# Altium schematic sheet Controller.SchDoc
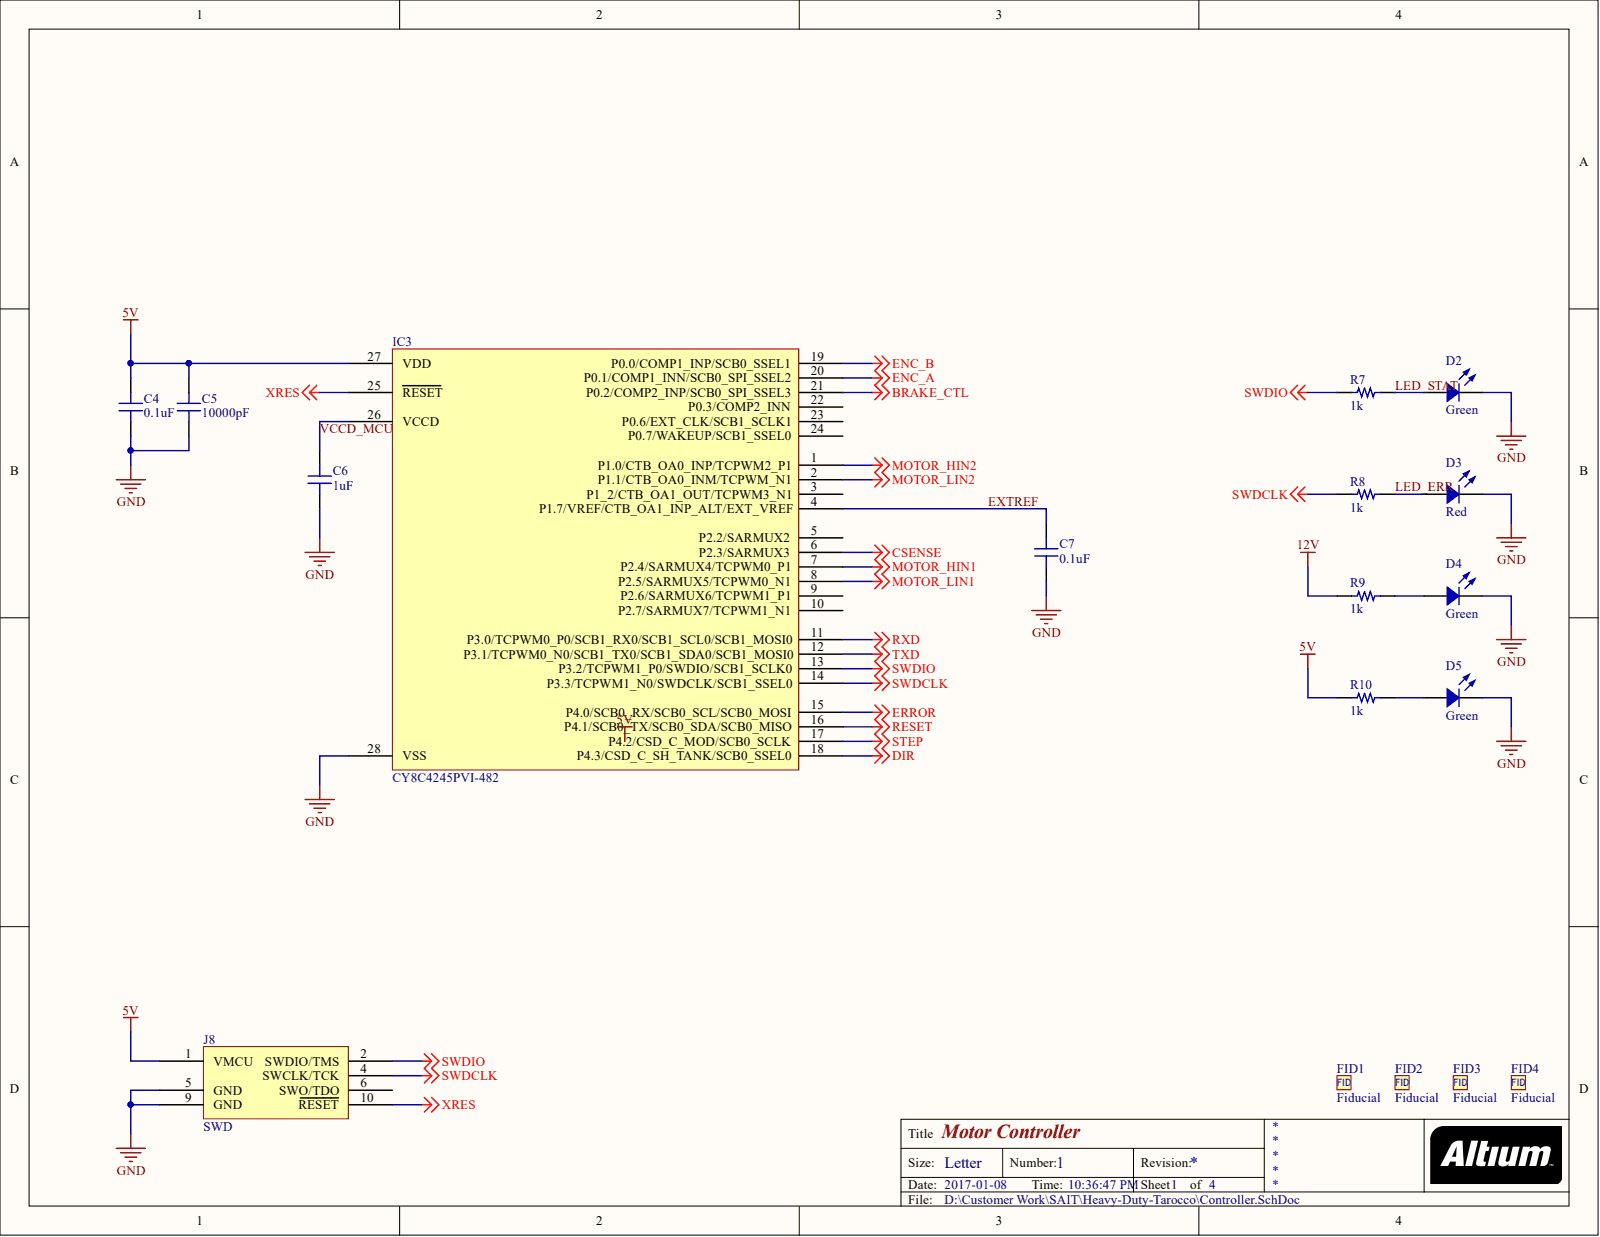
[diagram p0: Controller.SchDoc]

## Parts
C4  0.1uF  [CAP 0603/1608]
C5  10000pF  [CAP 0603/1608]
C6  1uF  [CAP 0603/1608]
C7  0.1uF  [CAP 0603/1608]
D2  Green  [LED 0603/1608 GREEN]
D3  Red  [LED 0603/1608 RED]
D4  Green  [LED 0603/1608 GREEN]
D5  Green  [LED 0603/1608 GREEN]
FID1  Fiducial  [Fiducial]  (no BOM)
FID2  Fiducial  [Fiducial]  (no BOM)
FID3  Fiducial  [Fiducial]  (no BOM)
FID4  Fiducial  [Fiducial]  (no BOM)
IC3  CY8C4245PVI-482  [CYPRESS SSOP-28 210MIL]
J8  SWD  [10 Pin SWD]  (no BOM)
R7  1k  [RES 0603/1608]
R8  1k  [RES 0603/1608]
R9  1k  [RES 0603/1608]
R10  1k  [RES 0603/1608]

## Nets
5V: C4.2 C5.2 IC3.27(VDD) J8.1(VMCU) R10.1
12V: R9.1
BRAKE_CTL: IC3.21(P0.2/COMP2_INP/SCB0_SPI_SSEL3)
CSENSE: IC3.6(P2.3/SARMUX3)
DIR: IC3.18(P4.3/CSD_C_SH_TANK/SCB0_SSEL0)
ENC_A: IC3.20(P0.1/COMP1_INN/SCB0_SPI_SSEL2)
ENC_B: IC3.19(P0.0/COMP1_INP/SCB0_SSEL1)
ERROR: IC3.15(P4.0/SCB0_RX/SCB0_SCL/SCB0_MOSI)
GND: C4.1 C5.1 C6.1 C7.1 D2.2 D3.2 D4.2 D5.2 IC3.28(VSS) J8.5(GND) J8.9(GND)
MOTOR_HIN1: IC3.7(P2.4/SARMUX4/TCPWM0_P1)
MOTOR_HIN2: IC3.1(P1.0/CTB_OA0_INP/TCPWM2_P1)
MOTOR_LIN1: IC3.8(P2.5/SARMUX5/TCPWM0_N1)
MOTOR_LIN2: IC3.2(P1.1/CTB_OA0_INM/TCPWM_N1)
RESET: IC3.16(P4.1/SCB0_TX/SCB0_SDA/SCB0_MISO)
RXD: IC3.11(P3.0/TCPWM0_P0/SCB1_RX0/SCB1_SCL0/SCB1_MOSI0)
STEP: IC3.17(P4.2/CSD_C_MOD/SCB0_SCLK)
SWDCLK: IC3.14(P3.3/TCPWM1_N0/SWDCLK/SCB1_SSEL0) J8.4(SWCLK/TCK) R8.1
SWDIO: IC3.13(P3.2/TCPWM1_P0/SWDIO/SCB1_SCLK0) J8.2(SWDIO/TMS) R7.1
TXD: IC3.12(P3.1/TCPWM0_N0/SCB1_TX0/SCB1_SDA0/SCB1_MOSI0)
XRES: IC3.25(RESET) J8.10(RESET)
EXTREF: C7.2 IC3.4(P1.7/VREF/CTB_OA1_INP_ALT/EXT_VREF)
LED_ERR: D3.1 R8.2
LED_STAT: D2.1 R7.2
VCCD_MCU: C6.2 IC3.26(VCCD)
NetD4_1: D4.1 R9.2
NetD5_1: D5.1 R10.2

Not connected: IC3 3(P1_2/CTB_OA1_OUT/TCPWM3_N1) 5(P2.2/SARMUX2) 9(P2.6/SARMUX6/TCPWM1_P1) 10(P2.7/SARMUX7/TCPWM1_N1) 22(P0.3/COMP2_INN) 23(P0.6/EXT_CLK/SCB1_SCLK1) 24(P0.7/WAKEUP/SCB1_SSEL0); J8 6(SWO/TDO)

## Library data of the parts
C4 = CC0603KPX7R7BB104: CAP CER 0.1UF 16V X7R 0603; Manufacturer: Yageo; Database Table Name: Capacitor - Ceramic; TemperatureRange: -55C ~ 125C; Tolerance: 10%; Component Kind: Standard; ComponentLink1Description: Datasheet; ComponentLink1URL: http://www.yageo.com/documents/recent/UPY-GPHC_X7R_6.3V-to-50V-v12.pdf; ComponentLink2Description: Digi-Key listing; ComponentLink2URL: http://digikey.com/product-detail/en/CC0603KPX7R7BB104/311-1335-2-ND/2103073; Device Package: 0603 (1608 Metric); Library Name: Celestial Library Database.DbLib; PartId: 5262; Part Number: CC0603KPX7R7BB104; Price: 0.0043; Supplier 1: Digi-Key; Supplier Part Number 1: 311-1335-2-ND; TemperatureCoefficient: X7R; Type: -; Value: 0.1uF; Voltage: 16V
C5 = CL10B103KB8NCNC: CAP CER 10000PF 50V X7R 0603; Manufacturer: Samsung Electro-Mechanics America, Inc.; Database Table Name: Capacitor - Ceramic; TemperatureRange: -55C ~ 125C; Tolerance: 10%; Component Kind: Standard; ComponentLink1Description: Datasheet; ComponentLink1URL: http://www.samsungsem.com/kr/support/library/product-catalog/__icsFiles/afieldfile/2015/11/18/MLCC.pdf; ComponentLink2Description: Digi-Key Listing; ComponentLink2URL: https://www.digikey.com/product-detail/en/samsung-electro-mechanics-america-inc/CL10B103KB8NCNC/1276-1921-2-ND/3887579; Device Package: 0603 (1608 Metric); Library Name: Celestial Library Database.DbLib; PartId: 13008; Part Number: CL10B103KB8NCNC; Price: 0.0032; Supplier 1: Digi-Key; Supplier Part Number 1: 1276-1921-2-ND; TemperatureCoefficient: X7R; Value: 10000pF; Voltage: 50V
C6 = CC0603ZRY5V7BB105: CAP CER 1UF 16V Y5V 0603; Manufacturer: Yageo; Database Table Name: Capacitor - Ceramic; TemperatureCoefficient: Y5V (F); TemperatureRange: -30C ~ 85C; Tolerance: -20%, +80%; Component Kind: Standard; ComponentLink1Description: Datasheet; ComponentLink1URL: http://www.yageo.com/documents/recent/UPY-GPHC_Y5V_6.3V-to-50V_6.pdf; ComponentLink2Description: Digi-Key Listing; ComponentLink2URL: https://www.digikey.com/product-detail/en/yageo/CC0603ZRY5V7BB105/311-1372-2-ND/2103110; Device Package: 0603 (1608 Metric); Library Name: Celestial Library Database.DbLib; PartId: 13115; Part Number: CC0603ZRY5V7BB105; Price: 0.0063; Supplier 1: Digi-Key; Supplier Part Number 1: 311-1372-2-ND; Value: 1uF; Voltage: 16V
C7 = CC0603JRX7R8BB104: CAP CER 0.1UF 25V X7R 0603; Manufacturer: Yageo; Database Table Name: Capacitor - Ceramic; TemperatureRange: -55C ~ 125C; Tolerance: 5%; Component Kind: Standard; ComponentLink1Description: Datasheet; ComponentLink1URL: http://www.yageo.com/documents/recent/UPY-GPHC_X7R_6.3V-to-50V-v12.pdf; ComponentLink2Description: Digi-Key listing; ComponentLink2URL: http://digikey.com/product-detail/en/CC0603JRX7R8BB104/311-1777-2-ND/5195186; Device Package: 0603 (1608 Metric); Library Name: Celestial Library Database.DbLib; PartId: 5305; Part Number: CC0603JRX7R8BB104; Price: 0.0079; Supplier 1: Digi-Key; Supplier Part Number 1: 311-1777-2-ND; TemperatureCoefficient: X7R; Type: -; Value: 0.1uF; Voltage: 25V
D2 D4 D5 = LTST-C191KGKT: LED GREEN CLEAR 0603 SMD; Manufacturer: Lite-On Inc.; Current (Test): 20mA; Database Table Name: Chip LED; Millicandela: 35mcd; Type: Surface Mount; Viewing Angle: 130; Voltage Forward: 2V; Colour: Green; Component Kind: Standard; ComponentLink1Description: Datasheet; ComponentLink1URL: http://optoelectronics.liteon.com/upload/download/DS22-2000-228/S_110_LTST-C191KGKT.pdf; ComponentLink2Description: Digi-Key Listing; ComponentLink2URL: http://www.digikey.ca/product-detail/en/LTST-C191KGKT/160-1446-2-ND/386835; Device Package: 0603 (1608 Metric); Lens Transparency: Clear; Library Name: Celestial Library Database.DbLib; PartId: 7051; Part Number: LTST-C191KGKT; Price: 0.0521; Supplier 1: Digi-Key; Supplier Part Number 1: 160-1446-2-ND; Value: Green
D3 = LTST-C191KRKT: LED RED CLEAR 0603 SMD; Manufacturer: Lite-On Inc.; Current (Test): 20mA; Database Table Name: Chip LED; Millicandela: 54mcd; Value: Green; Viewing Angle: 130; Voltage Forward: 2V; Colour: Red; Component Kind: Standard; ComponentLink1Description: Datasheet; ComponentLink1URL: http://optoelectronics.liteon.com/upload/download/DS22-2000-223/S_110_LTST-C191KRKT.pdf; ComponentLink2Description: Digi-Key Listing; ComponentLink2URL: http://www.digikey.ca/product-detail/en/LTST-C191KRKT/160-1447-2-ND/386837; Device Package: 0603 (1608 Metric); Lens Transparency: Clear; Library Name: Celestial Library Database.DbLib; PartId: 7050; Part Number: LTST-C191KRKT; Price: 0.0521; Supplier 1: Digi-Key; Supplier Part Number 1: 160-1447-2-ND
IC3 = CY8C4245PVI-482: IC MCU 32BIT 32KB FLASH 28SSOP; Manufacturer: Cypress Semiconductor Corp; Connectivity: IC, IrDA, LIN, Microwire, SmartCard, SPI, SSP, UART/USART; Core Processor: ARM Cortex-M0; Core Size: 32-Bit; Database Table Name: MCU - ARM; Data Converters: A/D 8x12b; Number Of IO: 22; Oscillator Type: Internal; Peripherals: Brown-out Detect/Reset, CapSense, LCD, LVD, POR, PWM, WDT; Program Memory Size: 32KB (32K x 8); Ram Size: 4K x 8; Speed: 48MHz; Voltage: 1.71 V ~ 5.5 V; Component Kind: Standard; ComponentLink1Description: Datasheet; ComponentLink1URL: http://www.cypress.com/?docID=46322; ComponentLink2Description: Digi-Key Listing; ComponentLink2URL: https://www.digikey.com/product-detail/en/cypress-semiconductor-corp/CY8C4245PVI-482/CY8C4245PVI-482-ND/4047448; Device Package: 28-SSOP (0.209", 5.30mm Width); Library Name: Celestial Library Database.DbLib; PartId: 21202; Part Number: CY8C4245PVI-482; Price: 3.75; Program Memory Type: FLASH; Series: PSOC® 4 CY8C42xx; Supplier 1: Digi-Key; Supplier Part Number 1: CY8C4245PVI-482-ND
R7-R10 = ERJ-3GEYJ102V: RES SMD 1K OHM 5% 1/10W 0603; Manufacturer: Panasonic Electronic Components; Database Table Name: Resistor - Chip; PowerRating: 0.1W, 1/10W; TemperatureCoefficient: 200ppm/C; TemperatureRange: -55C ~ 155C; Component Kind: Standard; ComponentLink1Description: Datasheet; ComponentLink1URL: http://industrial.panasonic.com/www-cgi/jvcr13pz.cgi?E+PZ+3+AOA0001+ERJ3GEYJ102V+7+WW; ComponentLink2Description: Digi-Key Listing; ComponentLink2URL: https://www.digikey.com/product-detail/en/panasonic-electronic-components/ERJ-3GEYJ102V/P1.0KGTR-ND/68472; Device Package: 0603 (1608 Metric); Library Name: Celestial Library Database.DbLib; PartId: 11176; Part Number: ERJ-3GEYJ102V; Price: 0.002; Supplier 1: Digi-Key; Supplier Part Number 1: P1.0KGTR-ND; Tolerance: 5%; Value: 1k
FID1-FID4 = Fiducial: Library Name: Motor Controller.SchLib
J8 = SWD: Library Name: Motor Controller.SchLib
